# Altium schematic sheet DCDC.SchDoc
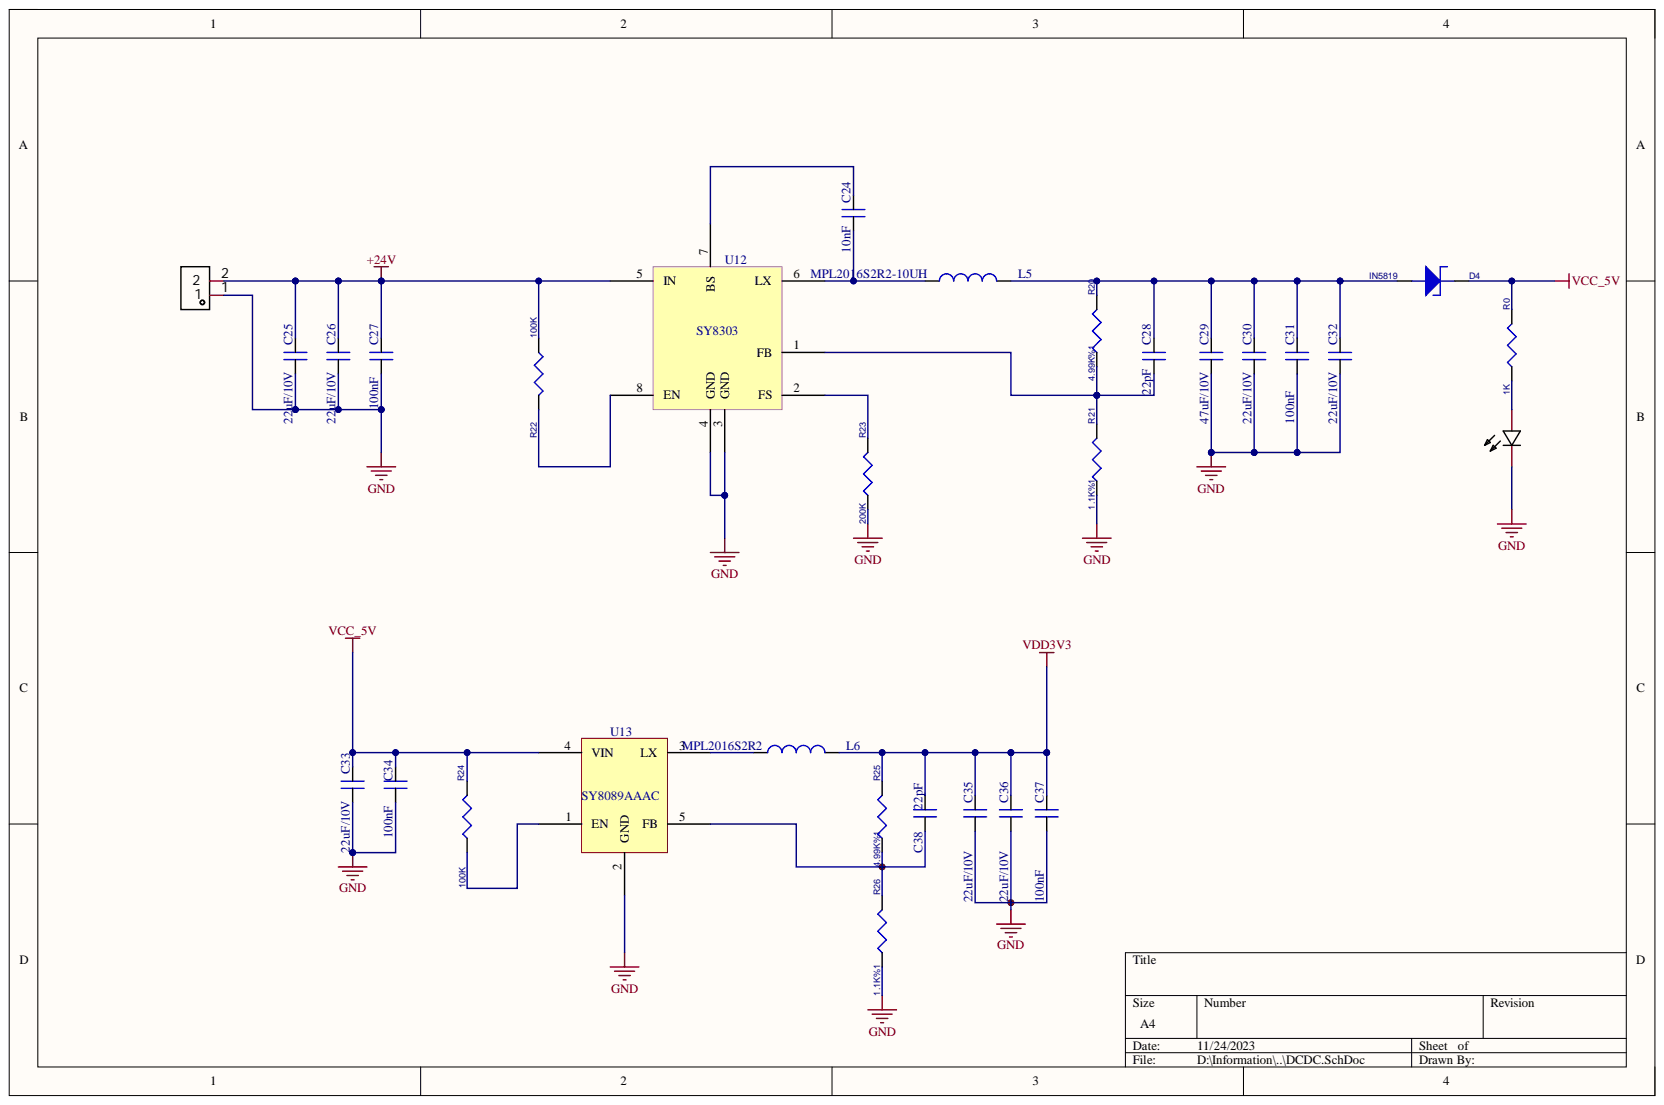
[diagram p0: DCDC.SchDoc]

## Parts
C24  10nF  [LC-0603_C]
C25  22uF/10V  [CAP-TH_BD10.0-P5.00-D1.0-FD]
C26  22uF/10V  [LC-0805_C]
C27  100nF  [LC-0603_C]
C28  22pF  [LC-0402_C]
C29  47uF/10V  [LC-1210_C]
C30  22uF/10V  [LC-0805_C]
C31  100nF  [LC-0603_C]
C32  22uF/10V  [LC-1210_C]
C33  22uF/10V  [LC-0805_C]
C34  100nF  [LC-0603_C]
C35  22uF/10V  [LC-0805_C]
C36  22uF/10V  [LC-0805_C]
C37  100nF  [LC-0603_C]
C38  22pF  [LC-0402_C]
CN?  KF250NH-3.81-2P  [CONN-TH_KF250NH-3.81-2P]  (value not drawn)
D4  IN5819  [DO-214AC]
L5  MPL2016S2R2-10UH  [IND-SMD_L3.0-W3.0_SWPA3010S]
L6  MPL2016S2R2  [IND-SMD_L2.5-W2.0]
LED?  NCD0603R1_C84263  [LED0603-RD_RED]  (value not drawn)
R0  1K  [LC-0402_R]
R20  4.99K%1  [LC-0402_R]
R21  1.1K%1  [LC-0402_R]
R22  100K  [LC-0402_R]
R23  200K  [LC-0402_R]
R24  100K  [LC-0402_R]
R25  4.99K%1  [LC-0402_R]
R26  1.1K%1  [LC-0402_R]
U12  SY8303  [TSOT-23-8_L2.9-W1.6-P0.65-LS2.8-BR]
U13  SY8089AAAC  [LC-SOT-23-5]

## Nets
+24V: C25.1 C26.1 C27.1 CN?.2 R22.1 U12.5(IN)
GND: C25.2 C26.2 C27.2 C29.2 C30.2 C31.2 C32.2 C33.2 C34.2 C35.2 C36.2 C37.2 CN?.1 LED?.1 R21.1 R23.1 R26.1 U12.3(GND) U12.4(GND) U13.2(GND)
VCC_5V: C33.1 C34.1 D4.K R0.2 R24.2 U13.4(VIN)
VDD3V3: C35.1 C36.1 C37.1 C38.1 L6.2 R25.2
NetC24_1: C24.1 U12.7(BS)
NetC24_2: C24.2 L5.1 U12.6(LX)
NetC28_1: C28.1 C29.1 C30.1 C31.1 C32.1 D4.A L5.2 R20.2
NetC28_2: C28.2 R20.1 R21.2 U12.1(FB)
NetC38_2: C38.2 R25.1 R26.2 U13.5(FB)
NetL6_1: L6.1 U13.3(LX)
NetLED?_2: LED?.2 R0.1
NetR22_2: R22.2 U12.8(EN)
NetR23_2: R23.2 U12.2(FS)
NetR24_1: R24.1 U13.1(EN)

## Library data of the parts
C24-C38 = C: Component Kind: Standard; Library Name: K210--Crazy.SCHLIB
CN? = KF250NH-3.81-2P: Manufacturer: KEFA(科发); Add into BOM: yes; Current Rating (Max): 15A; Number of PINs Per Row: 2; Pitch: 3.81mm; Voltage Rating (Max): 250V; Wire Gauge - AWG: 16~20; Wire Gauge - mm2: 1.5; Color: 绿色; Component Kind: Standard; Convert to PCB: yes; Datasheet: https://atta.szlcsc.com/upload/public/pdf/source/20210104/C976575_A0E34178A64A6FB2BFF412AB39272803.pdf; Device: KF250NH-3.81-2P; JLCPCB Part Class: Extended Part; LCSC Part Name: 3.81mm 每排P数:2 排数:1; Manufacturer Part: KF250NH-3.81-2P; Mounting Style: 通孔; Name: ='KEFA(科发)'Part; Number of Rows: 1; Operating Temperature Range: -40℃~+105℃; Supplier: LCSC; Supplier Footprint: 插件,P=3.81mm; Supplier Part: C976576; Symbol: KF250NH-3.81-2P
D4 = DIODE ZENER_4_1: room: power1; Component Kind: Standard; Library Name: 光学蓝牙.SCHLIB
L5 L6 = Inductor: Code_IEC: 1005; Value: 10mH; Code_JEITA: 0402; Component Kind: Standard; Description: Inductor; LatestRevisionDate: 29-May-2009; LatestRevisionNote: IPC-7351 Footprint Added.; Library Name: Miscellaneous Devices.IntLib; PackageDescription: Chip Inductor; PackageReference: 0402-A; Published: 8-Jun-2000; Publisher: Altium Limited
LED? = NCD0603R1_C84263: Manufacturer: 国星光电; Add into BOM: yes; Emitted Color: 红灯; Symbol: FC-DA1608HRK-620D; Component Kind: Standard; Convert to PCB: yes; Datasheet: https://atta.szlcsc.com/upload/public/pdf/source/20200820/C84263_DABC6A75E58F576973B407FDBA283D60.pdf; Device: NCD0603R1_C84263; JLCPCB Part Class: Extended Part; LCSC Part Name: 红灯; Manufacturer Part: NCD0603R1; Name: ='国星光电'Part; PCB3D: LED0603-RD_RED; Supplier: LCSC; Supplier Footprint: 0603; Supplier Part: C84263
R0 R20-R26 = Res0603: Component Kind: Standard; Library Name: K210--Crazy.SCHLIB
U12 = SY8025: Component Kind: Standard; Library Name: 885阿里云PCB_Project1.SCHLIB
U13 = SY8089AAAC: Component Kind: Standard; Library Name: K210--Crazy.SCHLIB
